# Altium schematic sheet 102-002-00131-00,K12光照传感器V1.0原理图.SchDoc
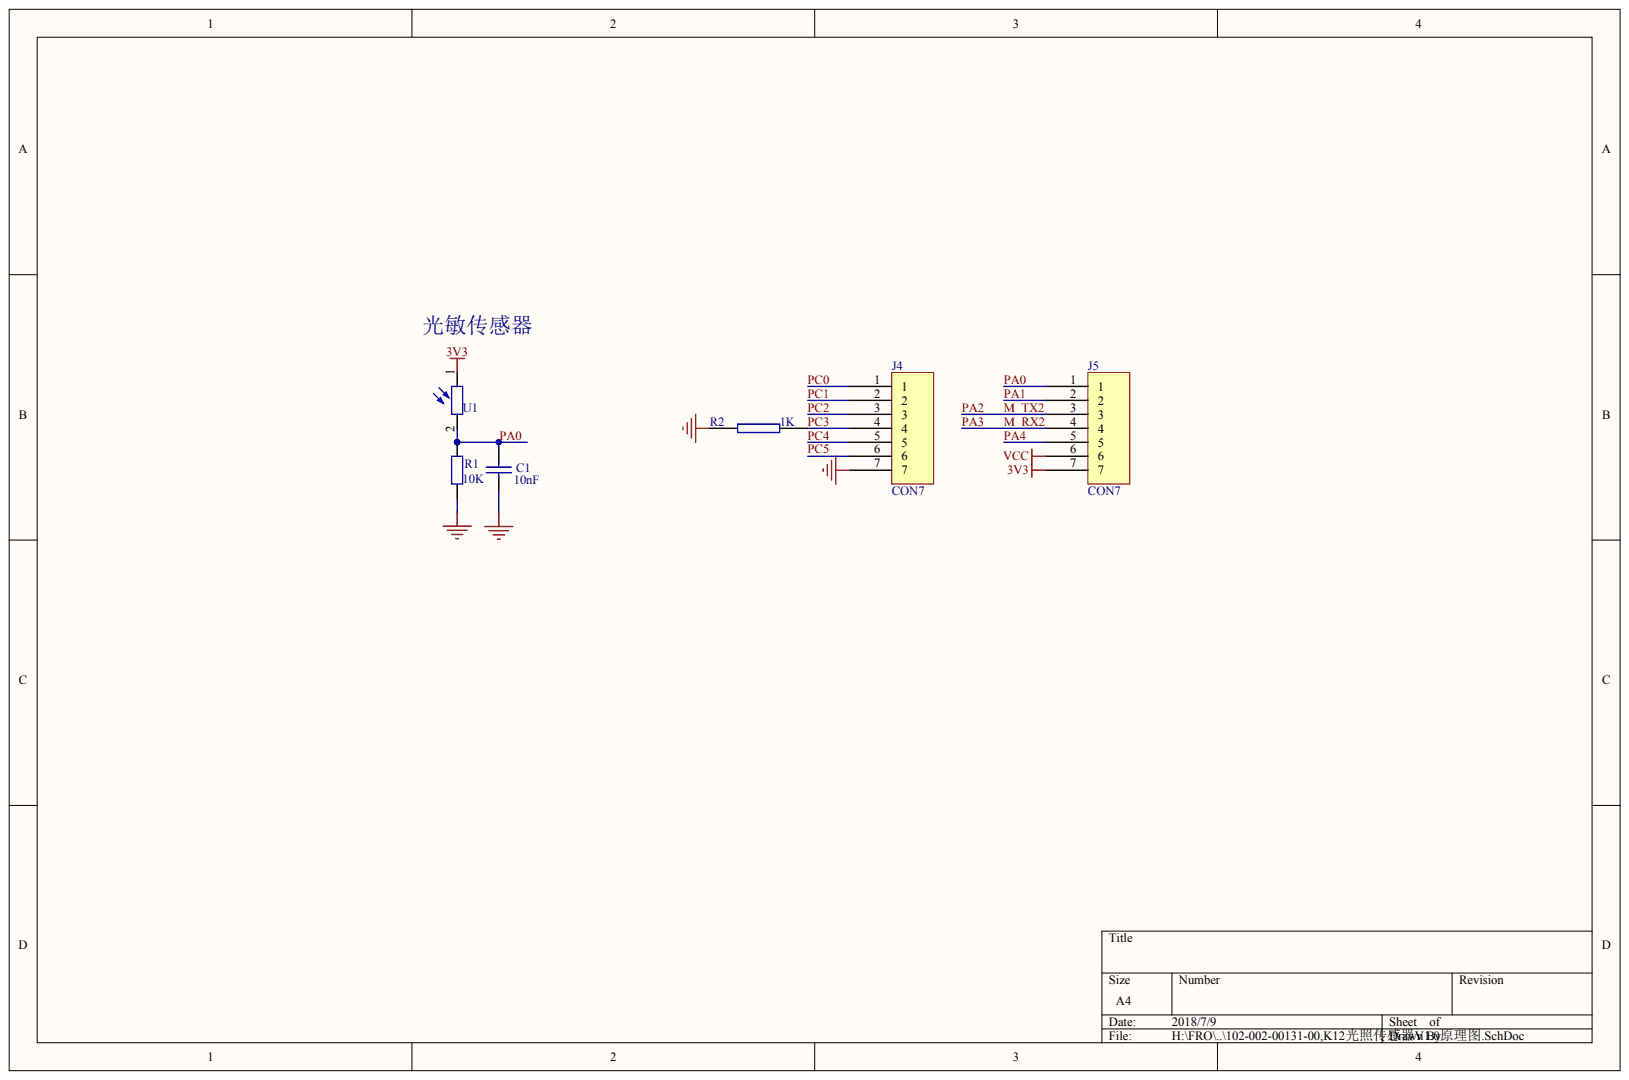
[diagram p0: 102-002-00131-00,K12光照传感器V1.0原理图.SchDoc]

## Parts
C1  10nF
J4  CON7
J5  CON7
R1  10K
R2  1K
U1  Guangmin  (value not drawn)

## Nets
3V3: J5.7 U1.1
GND: C1.1 J4.7 R1.2 R2.1
VCC: J5.6
M_RX2: J5.4
M_TX2: J5.3
PA0: C1.2 J5.1 R1.1 U1.2
PA1: J5.2
PA4: J5.5
PC0: J4.1
PC1: J4.2
PC2: J4.3
PC3: J4.4 R2.2
PC4: J4.5
PC5: J4.6

## Library data of the parts
C1 = (no symbol name): wuliaobianma: 104-001-00103-50; wuliaoguige: 0805/10nF/50V/X7R/J; wuliaomingchen: 贴片无极电容
R1 = (no symbol name): wuliaoguige: 0805/10KΩ/F; wuliaobianma: 103-001-00103-01; wuliaomingchen: 贴片电阻
R2 = (no symbol name): wuliaobianma: 103-001-00102-01; wuliaoguige: 0805/1KΩ/F; wuliaomingchen: 贴片电阻
U1 = (no symbol name): wuliaobianma: 103-005-00001-00; wuliaomingchen: 光敏电阻; wuliaoguige: GM5516
